# Altium schematic sheet DriverPrototype.SchDoc
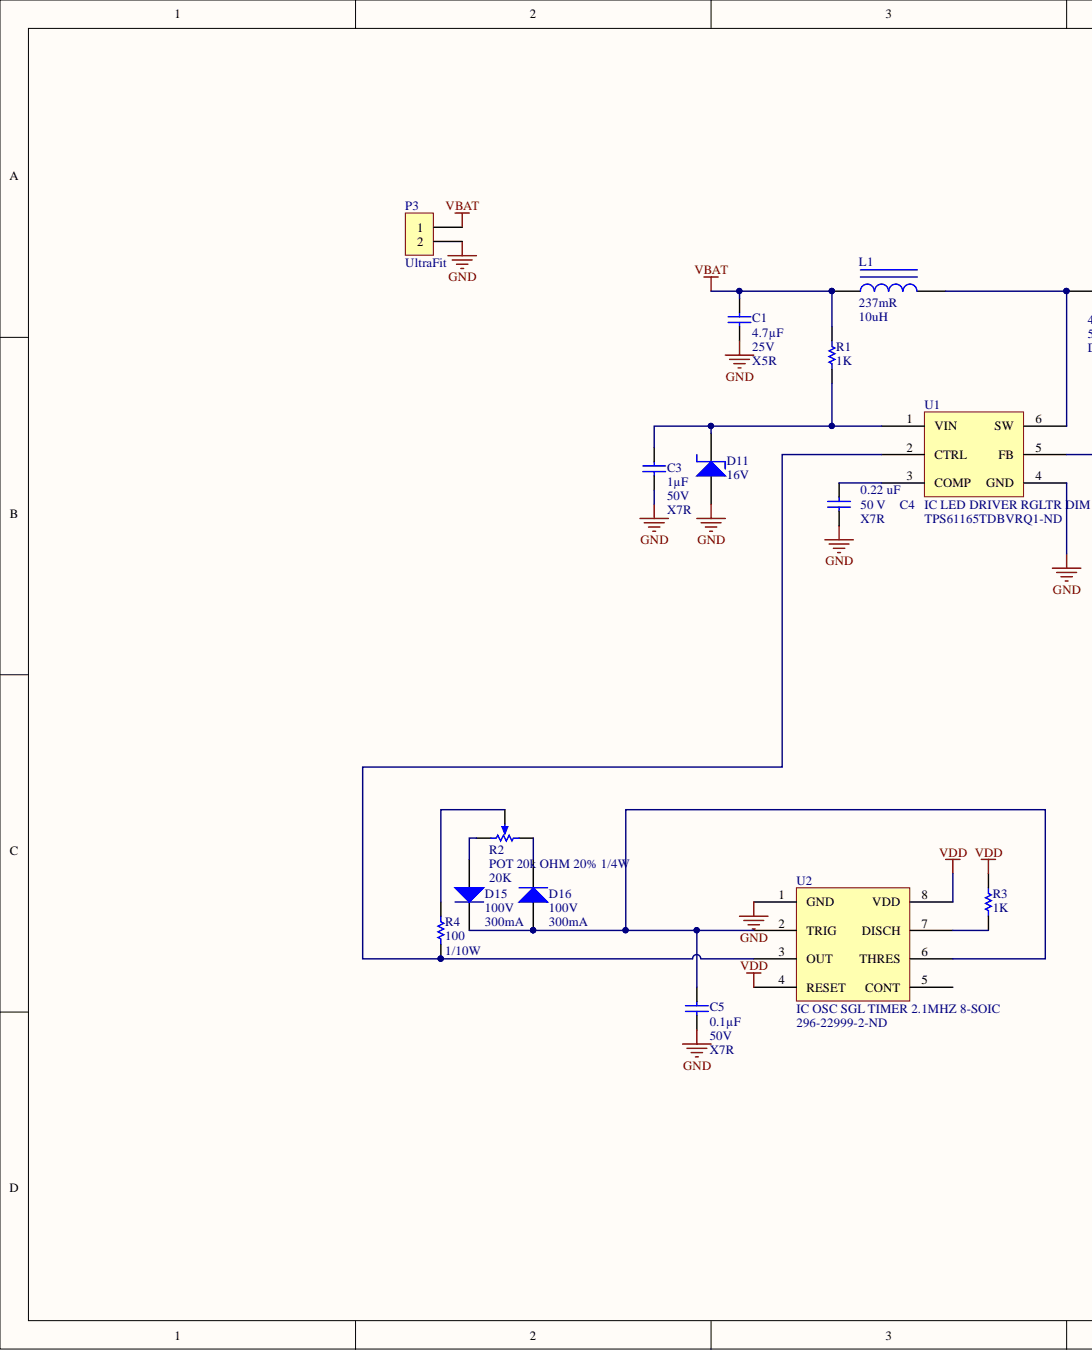
[diagram p0: DriverPrototype.SchDoc, left]
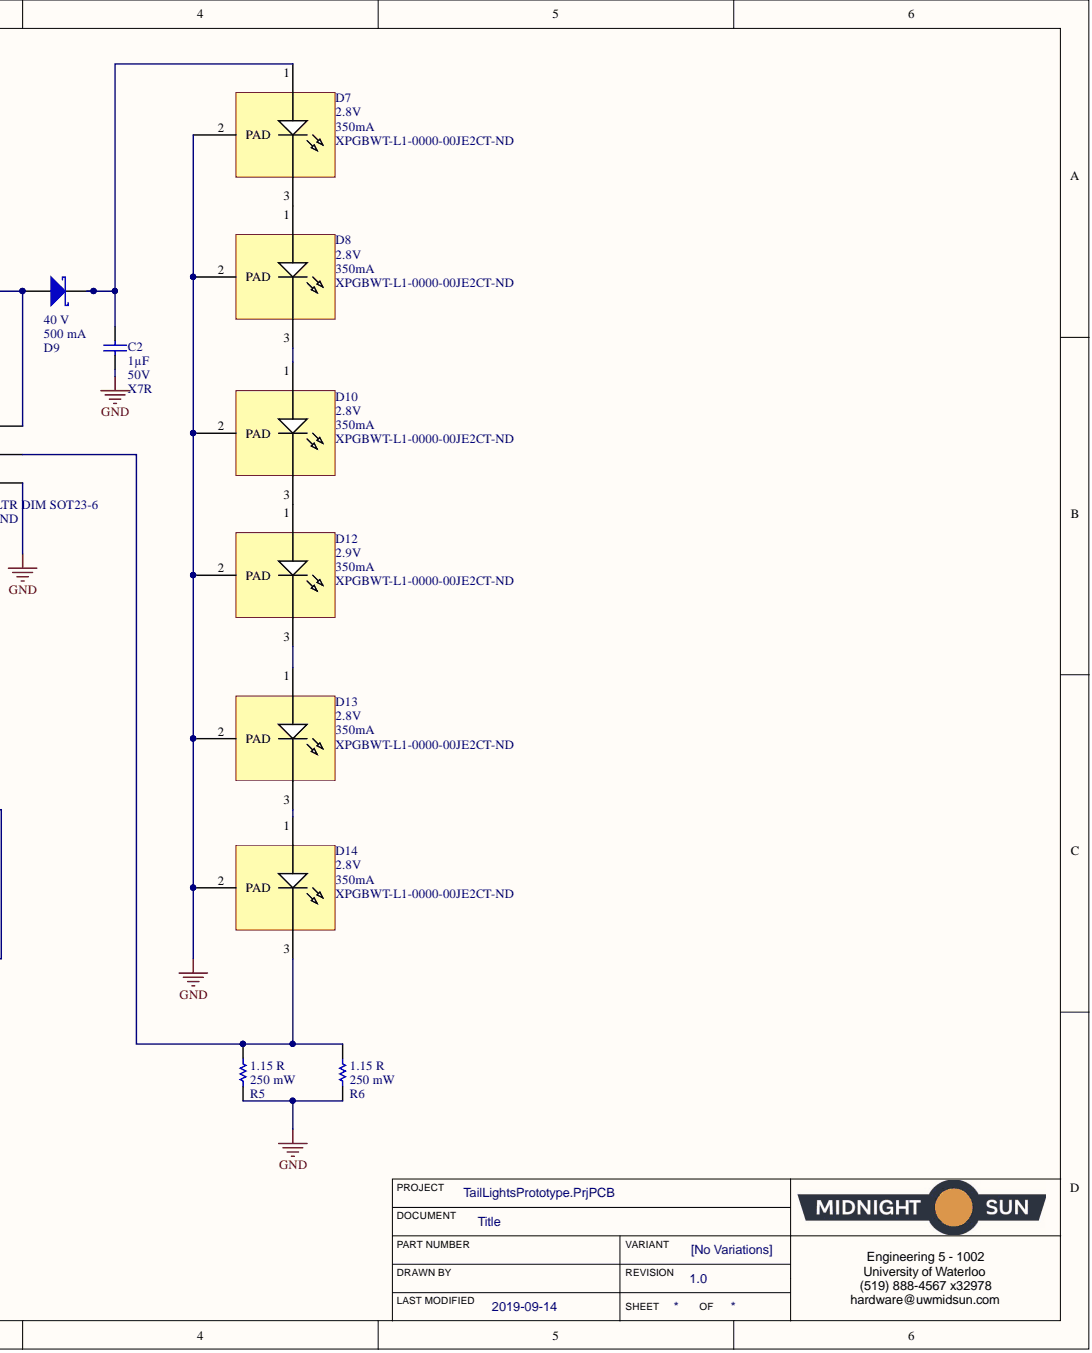
[diagram p1: DriverPrototype.SchDoc, right]

## Parts
C1  Capacitance: 4.7µF  Temperature Coefficient: X5R  Voltage: 25V  [CAP, 0603]  (hidden comment: CAP CER 4.7UF 25V 10% X5R 0603)
C2  Capacitance: 1µF  Temperature Coefficient: X7R  Voltage: 50V  [CAP, 0603]  (hidden comment: CAP CER 1UF 50V 10% X7R 0603)
C3  Capacitance: 1µF  Voltage: 50V  [CAP, 0603]  (hidden comment: CAP CER 1UF 50V 10% X7R 0603)
C4  Dielectric: X7R  [CAP, 0805]  (hidden comment: CAP CER 0.22UF 50V X7R 0805)
C5  Capacitance: 0.1µF  Temperature Coefficient: X7R  Voltage: 50V  [CAP, 0603]  (hidden comment: CAP CER 0.1UF 50V 10% X7R 0603)
D7  Forward Voltage: 2.8V  Supplier Part Number 1: XPGBWT-L1-0000-00JE2CT-ND  Test Current: 350mA  [LED CREE XP-E2 1414 2-SMD]  (hidden comment: LED XLAMP COOL WHITE 5700K 2SMD)
D8  Forward Voltage: 2.8V  Supplier Part Number 1: XPGBWT-L1-0000-00JE2CT-ND  Test Current: 350mA  [LED CREE XP-E2 1414 2-SMD]  (hidden comment: LED XLAMP COOL WHITE 5700K 2SMD)
D9  DIODE SCHOTTKY 40V 500MA SOD123  [SOD123]  (value not drawn)
D10  Forward Voltage: 2.8V  Supplier Part Number 1: XPGBWT-L1-0000-00JE2CT-ND  Test Current: 350mA  [LED CREE XP-E2 1414 2-SMD]  (hidden comment: LED XLAMP COOL WHITE 5700K 2SMD)
D11  Voltage - Zener (Nom): 16V  [DO-214AA Diode]  (hidden comment: DIODE ZENER 16V 5W DO-214AA (SMB))
D12  Forward Voltage: 2.9V  Supplier Part Number 1: XPGBWT-L1-0000-00JE2CT-ND  Test Current: 350mA  [LED CREE XP-E2 1414 2-SMD]  (hidden comment: LED XLAMP COOL WHITE 5700K 2SMD)
D13  Forward Voltage: 2.8V  Supplier Part Number 1: XPGBWT-L1-0000-00JE2CT-ND  Test Current: 350mA  [LED CREE XP-E2 1414 2-SMD]  (hidden comment: LED XLAMP COOL WHITE 5700K 2SMD)
D14  Forward Voltage: 2.8V  Supplier Part Number 1: XPGBWT-L1-0000-00JE2CT-ND  Test Current: 350mA  [LED CREE XP-E2 1414 2-SMD]  (hidden comment: LED XLAMP COOL WHITE 5700K 2SMD)
D15  Forward Current: 300mA  Max Repetitive Reverse Voltage (Vrrm): 100V  [DIODE GEN PURP 100V 300MA SOD123]  (hidden comment: DIODE GEN PURP 100V 300MA SOD123)
D16  Forward Current: 300mA  Max Repetitive Reverse Voltage (Vrrm): 100V  [DIODE GEN PURP 100V 300MA SOD123]  (hidden comment: DIODE GEN PURP 100V 300MA SOD123)
L1  DC Resistance (DCR): 237mR  Inductance: 10uH  [INDP5050X20N]  (hidden comment: FIXED IND 10UH 1.13A 237 MOHM)
P3  Series: UltraFit  [CONN, 2POS ULTRA-FIT]  (hidden comment: CONN 2POS ULTRA-FIT 0.138")
R1  1K  [RES, 0603]
R2  POT 20k OHM 20% 1/4W  [20K POT]
R3  1K  [RES, 0603]
R4  Power: 1/10W  [RES, 0603]  (hidden comment: RES 100 OHM 1% 1/10W 0603)
R5  RES SMD 1.15 OHM 1% 1/4W 1206  [RES 1206]  (value not drawn)
R6  RES SMD 1.15 OHM 1% 1/4W 1206  [RES 1206]  (value not drawn)
U1  IC LED DRIVER RGLTR DIM SOT23-6  Supplier Part Number 1: TPS61165TDBVRQ1-ND  [SOT23-6_N]
U2  IC OSC SGL TIMER 2.1MHZ 8-SOIC  Supplier Part Number 1: 296-22999-2-ND  [SOIC8]

## Nets
GND: C1.2 C2.2 C3.2 C4.2 C5.1 D7.2 D8.2 D10.2 D11.1 D12.2 D13.2 D14.2 P3.2 R5.2 R6.2 U1.4(GND) U2.1(GND)
VBAT: C1.1 L1.1 P3.1 R1.1
VDD: R3.1 U2.4(RESET) U2.8(VDD)
NetC2_1: C2.1 D7.1 D9.2
NetC3_1: C3.1 D11.2 R1.2 U1.1(VIN)
NetC4_1: C4.1 U1.3(COMP)
NetC5_2: C5.2 D15.2 D16.1 U2.2(TRIG) U2.6(THRES)
NetD7_3: D7.3 D8.1
NetD8_3: D8.3 D10.1
NetD9_1: D9.1 L1.2 U1.6(SW)
NetD10_3: D10.3 D12.1
NetD12_3: D12.3 D13.1
NetD13_3: D13.3 D14.1
NetD14_3: D14.3 R5.1 R6.1 U1.5(FB)
NetD15_1: D15.1 R2.3
NetD16_2: D16.2 R2.1
NetR2_2: R2.2 R4.1
NetR3_2: R3.2 U2.7(DISCH)
NetR4_2: R4.2 U1.2(CTRL) U2.3(OUT)

Not connected: U2 5(CONT)

## Library data of the parts
C1 = CAP CER 4.7UF 25V 10% X5R 0603: 4.7µF ±10% 25V Ceramic Capacitor X5R 0603 (1608 Metric); Manufacturer 1: Murata Electronics North America; Manufacturer Part Number 1: GRM188R61E475KE11D; Component Kind: Standard; ComponentLink1Description: http://www.murata.com/~/media/webrenewal/support/library/catalog/products/capacitor/mlcc/c02e.ashx; ComponentLink1URL: http://www.murata.com/~/media/webrenewal/support/library/catalog/products/capacitor/mlcc/c02e.ashx; Height - Seated (Max): -; Lead Spacing: -; Lead Style: -; Mounting Type: Surface Mount, MLCC; Operating Temperature: -55°C ~ 85°C; Package / Case: 0603 (1608 Metric); Packaging: Cut Tape (CT); Part Status: Active; Ratings: -; Size / Dimension: 0.063" L x 0.031" W (1.60mm x 0.80mm); Supplier 1: Digi-Key; Supplier Part Number 1: 490-7203-1-ND; Thickness (Max): 0.031" (0.80mm); Tolerance: ±10%; Library Name: Schematic Diagrams.SchLib
C2 C3 = CAP CER 1UF 50V 10% X7R 0603: 1µF ±10% 50V Ceramic Capacitor X7R 0603 (1608 Metric); Manufacturer 1: Taiyo Yuden; Manufacturer Part Number 1: UMK107AB7105KA-T; Component Kind: Standard; ComponentLink1Description: http://www.yuden.co.jp/productdata/catalog/en/mlcc_all_e.pdf; ComponentLink1URL: http://www.yuden.co.jp/productdata/catalog/en/mlcc_all_e.pdf; Height - Seated (Max): -; Lead Spacing: -; Lead Style: -; Mounting Type: Surface Mount, MLCC; Operating Temperature: -55°C ~ 125°C; Package / Case: 0603 (1608 Metric); Packaging: Cut Tape (CT); Part Status: Active; Ratings: -; Size / Dimension: 0.063" L x 0.031" W (1.60mm x 0.80mm); Supplier 1: Digi-Key; Supplier Part Number 1: 587-3247-1-ND; Thickness (Max): 0.037" (0.95mm); Tolerance: ±10%; Library Name: Schematic Diagrams.SchLib
  C3: Temperature Coefficient: X7R
C4 = CAP CER 0.22UF 50V X7R 0805: Manufacturer: Samsung; Manufacturer Part Number: CL21B224KBFNNNE; Capacitance: 220nF; Tolerance: 10%; Case/Package: 0805; Case Code (Imperial): 0805; Case Code (Metric): 2012; Component Kind: Standard; ComponentLink1Description: https://www.verical.com/server-webapp/html/getDatasheet?partID=358363&src-supplier=Verical; ComponentLink1URL: https://www.verical.com/server-webapp/html/getDatasheet?partID=358363&src-supplier=Verical; Contact Plating: Tin; Depth: 1.25mm; Height: 1.25mm; Lead Free: Lead Free; Length: 2mm; Max Operating Temperature: 125°C; Min Operating Temperature: -55°C; Mount: Surface Mount; Package Quantity: 1; Packaging: Tape and Reel; Pricing 1: 1=0.11, 10=0.077, 100=0.0361, 500=0.02532, 1000=0.02096 (USD); Radiation Hardening: No; Stock 1: 219478; Supplier 1: Digi-Key; Supplier Part Number 1: 1276-1093-6-ND; Thickness: 0.053inch; Voltage: 50V; Voltage Rating: 50V; Voltage Rating (DC): 50V; Width: 0.049inch; Library Name: Schematic Diagrams.SchLib
C5 = CAP CER 0.1UF 50V 10% X7R 0603: 0.10µF ±10% 50V Ceramic Capacitor X7R 0603 (1608 Metric); Manufacturer 1: AVX Corporation; Manufacturer Part Number 1: 06035C104KAT2A; Component Kind: Standard; ComponentLink1Description: http://datasheets.avx.com/X7RDielectric.pdf; ComponentLink1URL: http://datasheets.avx.com/X7RDielectric.pdf; Height - Seated (Max): -; Lead Spacing: -; Lead Style: -; Mounting Type: Surface Mount, MLCC; Operating Temperature: -55°C ~ 125°C; Package / Case: 0603 (1608 Metric); Packaging: Cut Tape (CT); Part Status: Active; Ratings: -; Size / Dimension: 0.063" L x 0.032" W (1.60mm x 0.81mm); Supplier 1: Digi-Key; Supplier Part Number 1: 478-5052-1-ND; Thickness (Max): 0.035" (0.90mm); Tolerance: ±10%; Library Name: Schematic Diagrams.SchLib
D7 D8 D10 D12-D14 = LED XLAMP COOL WHITE 5700K 2SMD: LED Lighting XLamp® XP-E2 White, Cool 5700K 2.9V 350mA 110° 1414 (3535 Metric); Manufacturer: Cree; Manufacturer Part Number: XPGBWT-L1-0000-00JE2; Max Current Rating: 1.5A; Thermal Resistance of Package: 4°C/W; Viewing Angle: 115°; Case/Package: SMD/SMT; Color: White; Component Kind: Standard; ComponentLink1Description: http://www.mouser.com/ds/2/90/XLampXPE2-253473.pdf?src-supplier=Mouser; ComponentLink1URL: http://www.mouser.com/ds/2/90/XLampXPE2-253473.pdf?src-supplier=Mouser; ComponentLink2Description: http://www.cree.com/~/media/Files/Cree/LED%20Components%20and%20Modules/XLamp/Data%20and%20Binning/XLampXPE2.pdf?src-supplier=Digi-Key; ComponentLink2URL: http://www.cree.com/~/media/Files/Cree/LED%20Components%20and%20Modules/XLamp/Data%20and%20Binning/XLampXPE2.pdf?src-supplier=Digi-Key; ComponentLink3Description: https://www.verical.com/server-webapp/html/getDatasheet?partID=702662&src-supplier=Verical; ComponentLink3URL: https://www.verical.com/server-webapp/html/getDatasheet?partID=702662&src-supplier=Verical; Correlated Color Temperature (CCT): 5700K; Lead Free: Lead Free; Length: 3.45mm; Mount: Surface Mount; Packaging: Tape and Reel; Pricing 1: 1 = 1.47000, 10 = 1.40100, 100 = 1.25340, 250 = 1.13988, 500 = 1.06762 (USD); RoHS: Yes; Stock 1: 6024; Supplier 1: Digi-Key; Width: 3.45mm; Library Name: Schematic Diagrams.SchLib
D9 = DIODE SCHOTTKY 40V 500MA SOD123: Diode Schottky 40V 500mA Surface Mount SOD-123; Manufacturer: ON Semiconductor / Fairchild; Manufacturer Part Number: MBR0540; Current Rating: 500A; Element Configuration: Single; Forward Voltage: 620mV; Max Forward Surge Current (Ifsm): 5.5A; Max Reverse Leakage Current: 20uA; Polarity: Standard; Power Dissipation: 400mW; Average Rectified Current: 500mA; Component Kind: Standard; ComponentLink1Description: datasheet; ComponentLink1URL: https://www.onsemi.cn/PowerSolutions/document/MBR0540-D.pdf; ComponentLink2Description: supplier link; ComponentLink2URL: https://www.onsemi.com/PowerSolutions/product.do?id=MBR0540; ComponentLink3Description: https://www.fairchildsemi.com/datasheets/MB/MBR0540.pdf?src-supplier=Digi-Key; ComponentLink3URL: https://www.fairchildsemi.com/datasheets/MB/MBR0540.pdf?src-supplier=Digi-Key; ComponentLink4Description: https://www.onsemi.cn/PowerSolutions/document/MBR0540-D.pdf?src-supplier=Digi-Key; ComponentLink4URL: https://www.onsemi.cn/PowerSolutions/document/MBR0540-D.pdf?src-supplier=Digi-Key; ComponentLink5Description: http://www.jameco.com/Jameco/Products/ProdDS/975060.pdf?src-supplier=Jameco; ComponentLink5URL: http://www.jameco.com/Jameco/Products/ProdDS/975060.pdf?src-supplier=Jameco; ComponentLink6Description: http://www.mouser.com/ds/2/149/MBR0540-90522.pdf?src-supplier=Mouser; ComponentLink6URL: http://www.mouser.com/ds/2/149/MBR0540-90522.pdf?src-supplier=Mouser; ComponentLink7Description: https://www.verical.com/server-webapp/html/getDatasheet?partID=110131&src-supplier=Verical; ComponentLink7URL: https://www.verical.com/server-webapp/html/getDatasheet?partID=110131&src-supplier=Verical; ComponentLink8Description: http://www.farnell.com/datasheets/2041480.pdf?src-supplier=Element14; ComponentLink8URL: http://www.farnell.com/datasheets/2041480.pdf?src-supplier=Element14; ComponentLink9Description: https://www.fairchildsemi.com/datasheets/MB/MBR0540.pdf?src-supplier=Avnet; ComponentLink9URL: https://www.fairchildsemi.com/datasheets/MB/MBR0540.pdf?src-supplier=Avnet; Contact Plating: Tin,Matte; Forward Current: 500mA; Height: 1.03mm; Lead Free: Lead Free; Length: 2.7mm; Max Operating Temperature: 125°C; Max Repetitive Reverse Voltage (Vrrm): 40V; Max Reverse Voltage (DC): 40V; Max Surge Current: 5.5A; Min Operating Temperature: -65°C; Mount: Surface Mount; Number of Pins: 2; Output Current: 500mA; Package Quantity: 3000; Packaging: Tape and Reel; Peak Non-Repetitive Surge Current: 5.5A; Peak Reverse Current: 20uA; Pricing 1: 1=0.2, 10=0.166, 100=0.0881, 500=0.05794, 1000=0.0394 (USD); Radiation Hardening: No; REACH SVHC: No SVHC; Stock 1: 414620; Supplier 1: Digi-Key; Supplier Part Number 1: MBR0540DKR-ND; Voltage Rating (DC): 40V; Weight: 0.03g; Width: 1.6mm; Library Name: Schematic Diagrams.SchLib
D11 = DIODE ZENER 16V 5W DO-214AA (SMB): Zener Diode 16V 5W ±5% Surface Mount DO-214AA (SMB); Manufacture: Micro Commercial Co; Manufacture Part Number: SMBJ5353B-TP; Impedence (Max): 2.5 Ohm; Voltage - Forward: 1.2V @ 1A; Component Kind: Standard; Power (Max): 5W; Supplier 1: Digi-Key; Supplier Part Number 1: SMBJ5353B-TPMSCT-ND; Library Name: Schematic Diagrams.SchLib
D15 D16 = DIODE GEN PURP 100V 300MA SOD123: Diode Standard 100V 300mA (DC) Surface Mount SOD-123; Manufacturer: Diodes Zetex; Manufacturer Part Number: 1N4148WQ-7-F; Element Configuration: Single; Forward Voltage: 1.25V; Max Power Dissipation: 400mW; Max Surge Current: 2A; Peak Reverse Current: 50uA; Recovery Time: 4ns; Case/Package: SOD-123-2; Component Kind: Standard; ComponentLink1Description: http://components.arrow.com/part/dataview/0323_07206809; ComponentLink1URL: http://components.arrow.com/part/dataview/0323_07206809; ComponentLink2Description: http://www.diodes.com/datasheets/ds30086.pdf; ComponentLink2URL: http://www.diodes.com/datasheets/ds30086.pdf; ComponentLink3Description: http://www.mouser.com/ds/2/115/ds30086-318161.pdf; ComponentLink3URL: http://www.mouser.com/ds/2/115/ds30086-318161.pdf; Contact Plating: Tin,Matte; Lead Free: Lead Free; Max Operating Temperature: 150°C; Min Operating Temperature: -65°C; Mount: Surface Mount; Number of Pins: 2; Package Quantity: 3000; Packaging: Tape and Reel; Peak Non-Repetitive Surge Current: 2A; Pricing 1: 1=0.22, 10=0.196, 100=0.107, 500=0.0658, 1000=0.0449 (USD); Reverse Recovery Time: 4ns; Stock 1: 229; Supplier 1: Digi-Key; Supplier Part Number 1: 1N4148WQ-7-FDICT-ND; Weight: 0.000353oz; Library Name: Schematic Diagrams.SchLib
L1 = FIXED IND 10UH 1.13A 237 MOHM: 10µH Shielded Wirewound Inductor 1.13A 237mOhm Max Nonstandard; Manufacturer: TDK; Manufacturer Part Number: VLCF5020T-100M1R1-1; Core Material: Ferrite; Inductance Tolerance: 20%; RMS Current (Irms): 1.5A; Test Frequency: 100kHz; Case/Package: 2020; Component Kind: Standard; ComponentLink1Description: http://www.onlinecomponents.com/productFiles/mf-TDK/e531_vlcf5020_1.pdf?src-supplier=Onlinecomponents.com; ComponentLink1URL: http://www.onlinecomponents.com/productFiles/mf-TDK/e531_vlcf5020_1.pdf?src-supplier=Onlinecomponents.com; ComponentLink2Description: http://www.mouser.com/ds/2/400/e531_vlcf-18500.pdf?src-supplier=Mouser; ComponentLink2URL: http://www.mouser.com/ds/2/400/e531_vlcf-18500.pdf?src-supplier=Mouser; ComponentLink3Description: http://www.farnell.com/datasheets/516785.pdf?src-supplier=Element14; ComponentLink3URL: http://www.farnell.com/datasheets/516785.pdf?src-supplier=Element14; ComponentLink4Description: http://uk.rs-online.com/webdocs/1538/0900766b81538dbe.pdf?src-supplier=RSComponents; ComponentLink4URL: http://uk.rs-online.com/webdocs/1538/0900766b81538dbe.pdf?src-supplier=RSComponents; ComponentLink5Description: https://www.verical.com/server-webapp/html/getDatasheet?partID=107508&src-supplier=Verical; ComponentLink5URL: https://www.verical.com/server-webapp/html/getDatasheet?partID=107508&src-supplier=Verical; Composition: Wirewound; Current Rating: 1.13A; DC Current: 1.13A; Depth: 5.2mm; Height: 2mm; Height - Seated (Max): 0.079inch; Lead Free: Lead Free; Length: 0.197inch; Max DC Current: 1.13A; Max Operating Temperature: 105°C; Military Standard: Not; Min Operating Temperature: -40°C; Mount: Surface Mount; Number of Pins: 2; Package Quantity: 500; Packaging: Tape and Reel; Pricing 1: 500=0.441, 1000=0.357, 2500=0.336, 5000=0.3255, 12500=0.315, 25000=0.3045 (USD); Radiation Hardening: No; REACH SVHC: No SVHC; Saturation Current: 1.13A; Shielding: Shielded; Stock 1: 12500; Supplier 1: Digi-Key; Supplier Part Number 1: 445-3209-2-ND; Termination: SMD/SMT; Tolerance: 20%; Width: 0.197inch; Library Name: Schematic Diagrams.SchLib
P3 = CONN 2POS ULTRA-FIT 0.138": Connector Header Through Hole 2 position 0.138" (3.50mm); Manufacturer: Molex; Manufacturer Part Number: 1722871102; Connector Type: Male Pin; Number of Positions: 2; Pitch: 0.138inch; Color: Black; Component Kind: Standard; ComponentLink1Description: http://www.molex.com/pdm_docs/sd/1722861302_sd.pdf; ComponentLink1URL: http://www.molex.com/pdm_docs/sd/1722861302_sd.pdf; Contact Plating: Tin; Fastening Type: Latch; Mount: Through Hole; Number of Rows: 1; Packaging: Tray; Pricing 1: 1 = 0.78000, 10 = 0.68800, 100 = 0.59380, 500 = 0.48582, 1000 = 0.43184, 5000 = 0.37786, 10000 = 0.35897 (USD); RoHS: Yes; Stock 1: 2743; Supplier 1: Digi-Key; Supplier Part Number 1: WM11701-ND; Termination: Solder; Library Name: Schematic Diagrams.SchLib
R1 R3 = RES 1K OHM 5% 1/10W 0603: 1k Ohm ±5% 0.1W, 1/10W Chip Resistor 0603 (1608 Metric); Manufacturer: Yageo; Manufacturer Part Number: RC0603JR-071KL; Temperature Coefficient: ±100ppm/°C; Category: Resistors; Component Kind: Standard; ComponentLink1Description: http://www.yageo.com/documents/recent/PYu-RC_Group_51_RoHS_L_7.pdf; ComponentLink1URL: http://www.yageo.com/documents/recent/PYu-RC_Group_51_RoHS_L_7.pdf; Composition: Thick Film; Failure Rate: -; Features: Moisture Resistant; Height - Seated (Max): 0.022" (0.55mm); Operating Temperature: -55°C ~ 155°C; Package / Case: 0603 (1608 Metric); Packaging: Cut Tape (CT); Part Status: Active; Power (Watts): 0.1W, 1/10W; Pricing 1: 1=0.15, 10=0.017, 100=0.0071, 1000=0.0032, 2500=0.00278 (CAD); Resistance (Ohms): 1k; RoHS: RoHS Compliant; Size / Dimension: 0.063" L x 0.031" W (1.60mm x 0.80mm); Stock 1: 4415240; Supplier 1: Digi-Key; Supplier Device Package: 0603; Supplier Part Number 1: 311-1.0KGRCT-ND; Tolerance: ±5%; Library Name: Schematic Diagrams.SchLib
R2 = POT 20k OHM 20% 1/4W: 20k Ohm 0.25W, 1/4W Gull Wing Surface Mount Trimmer Potentiometer; Manufacture: TT Electronics/BI; Manufacture Part Number: 23BR20KLFTR; Adjustment Type: Top; Turns: 1; Component Kind: Standard; Power: 1/4W; Simulation: POT; Supplier 1: Digi-Key; Supplier Part Number 1: 987-1016-1-ND; Tolerence: 20%; Value: 20K; Library Name: Schematic Diagrams.SchLib
R4 = RES 100 OHM 1% 1/10W 0603: 100 Ohm ±1% 0.1W, 1/10W Chip Resistor 0603 (1608 Metric) Moisture Resistant Thick Film; Manufacturer 1: Yageo; Manufacturer Part Number 1: RC0603FR-07100RL; Resistance: 100; Temperature Coefficient: ±100ppm/°C; Component Kind: Standard; ComponentLink1Description: http://www.yageo.com/documents/recent/PYu-RC_Group_51_RoHS_L_7.pdf; ComponentLink1URL: http://www.yageo.com/documents/recent/PYu-RC_Group_51_RoHS_L_7.pdf; Composition: Thick Film; Failure Rate: -; Height - Seated (Max): 0.022" (0.55mm); Operating Temperature: -55°C ~ 155°C; Package / Case: 0603 (1608 Metric); Packaging: Cut Tape (CT); Part Status: Active; Size / Dimension: 0.063" L x 0.031" W (1.60mm x 0.80mm); Supplier 1: Digi-Key; Supplier Device Package: 0603; Supplier Part Number 1: 311-100HRCT-ND; Tolerance: ±1%; Library Name: Schematic Diagrams.SchLib
R5 R6 = RES SMD 1.15 OHM 1% 1/4W 1206: Manufacturer: Yageo; Manufacturer Part Number: RC1206FR-071R15L; Resistance: 1.15R; Temperature Coefficient: 200ppm/°C; Voltage Rating (DC): 200V; Case/Package: 1206; Component Kind: Standard; Composition: Thick Film; Contact Plating: Tin,Matte; Lead Free: Lead Free; Max Operating Temperature: 155°C; Min Operating Temperature: -55°C; Pricing 1: 1=0.15, 10=0.133, 100=0.0512, 1000=0.02252, 2500=0.01945 (USD); Radiation Hardening: No; Stock 1: 34622; Supplier 1: Digi-Key; Supplier Part Number 1: 311-1.15FRDKR-ND; Tolerance: 1%; Library Name: Schematic Diagrams.SchLib
U1 = IC LED DRIVER RGLTR DIM SOT23-6: LED Driver IC 1 Output DC DC Regulator Step-Up (Boost) PWM Dimming 1.2A (Switch) SOT-23-6; Manufacturer: Texas Instruments; Manufacturer Part Number: TPS61165TDBVRQ1; Frequency: 1.2MHz; Max Input Voltage: 18V; Max Supply Current: 2.3mA; Min Input Voltage: 3V; Number of Channels: 1; Number of Segments: 3; Output Voltage: 38V; Quiescent Current: 1.5mA; Case/Package: SOT-23-6; Component Kind: Standard; ComponentLink1Description: datasheet; ComponentLink1URL: http://www.ti.com/lit/ds/symlink/tps61165.pdf; ComponentLink2Description: http://www.ti.com/lit/gpn/tps61165-q1?src-supplier=Mouser; ComponentLink2URL: http://www.ti.com/lit/gpn/tps61165-q1?src-supplier=Mouser; ComponentLink3Description: https://www.verical.com/server-webapp/html/getDatasheet?partID=676299&src-supplier=Verical; ComponentLink3URL: https://www.verical.com/server-webapp/html/getDatasheet?partID=676299&src-supplier=Verical; ComponentLink4Description: http://www.ti.com/lit/ds/symlink/tps61165-q1.pdf?src-supplier=Digi-Key; ComponentLink4URL: http://www.ti.com/lit/ds/symlink/tps61165-q1.pdf?src-supplier=Digi-Key; Dimming: PWM; Lead Free: Lead Free; Max Operating Temperature: 105°C; Max Supply Voltage: 18V; Min Operating Temperature: -40°C; Min Supply Voltage: 3V; Number of Outputs: 1; Number of Pins: 6; Output Current: 1.2A; Output Current per Channel: 1.2A; Package Quantity: 3000; Packaging: Tape and Reel; Radiation Hardening: No; Stock 1: 0; Supplier 1: Digi-Key; Topology: Boost; Library Name: Schematic Diagrams.SchLib
U2 = IC OSC SGL TIMER 2.1MHZ 8-SOIC: 555 Type, Timer/Oscillator (Single) IC 2.1MHz 8-SOIC; Manufacturer: Texas Instruments; Manufacturer Part Number: TLC555QDRQ1; High Level Output Current: -10mA; Low Level Output Current: 100mA; Max Power Dissipation: 725mW; Max Supply Voltage: 15V; Min Supply Voltage: 2V; Nominal Supply Current: 170uA; Number of Timers/Counters: 1; Operating Supply Current: 360uA; Case/Package: SOIC; Component Kind: Standard; ComponentLink1Description: http://focus.ti.com/lit/ds/symlink/tlc555-q1.pdf?src-supplier=Avnet; ComponentLink1URL: http://focus.ti.com/lit/ds/symlink/tlc555-q1.pdf?src-supplier=Avnet; ComponentLink2Description: http://www.farnell.com/datasheets/1806066.pdf?src-supplier=Element14; ComponentLink2URL: http://www.farnell.com/datasheets/1806066.pdf?src-supplier=Element14; ComponentLink3Description: https://www.verical.com/server-webapp/html/getDatasheet?partID=297376&src-supplier=Verical; ComponentLink3URL: https://www.verical.com/server-webapp/html/getDatasheet?partID=297376&src-supplier=Verical; ComponentLink4Description: http://www.ti.com/lit/ds/symlink/tlc555-q1.pdf?src-supplier=Digi-Key; ComponentLink4URL: http://www.ti.com/lit/ds/symlink/tlc555-q1.pdf?src-supplier=Digi-Key; Contact Plating: Gold; Frequency: 2.1MHz; Lead Free: Lead Free; Max Operating Temperature: 125°C; Min Operating Temperature: -40°C; Mount: Surface Mount; Number of Pins: 8; Package Quantity: 2500; Packaging: Tape and Reel; Power Dissipation: 725mW; Pricing 1: 2500=0.3528, 5000=0.33516, 12500=0.32256 (USD); Quiescent Current: 170uA; Radiation Hardening: No; REACH SVHC: No SVHC; Stock 1: 60000; Supplier 1: Digi-Key; Weight: 0.002561oz; Library Name: Schematic Diagrams.SchLib
